# Altium schematic sheet Photo-interrupter.SchDoc
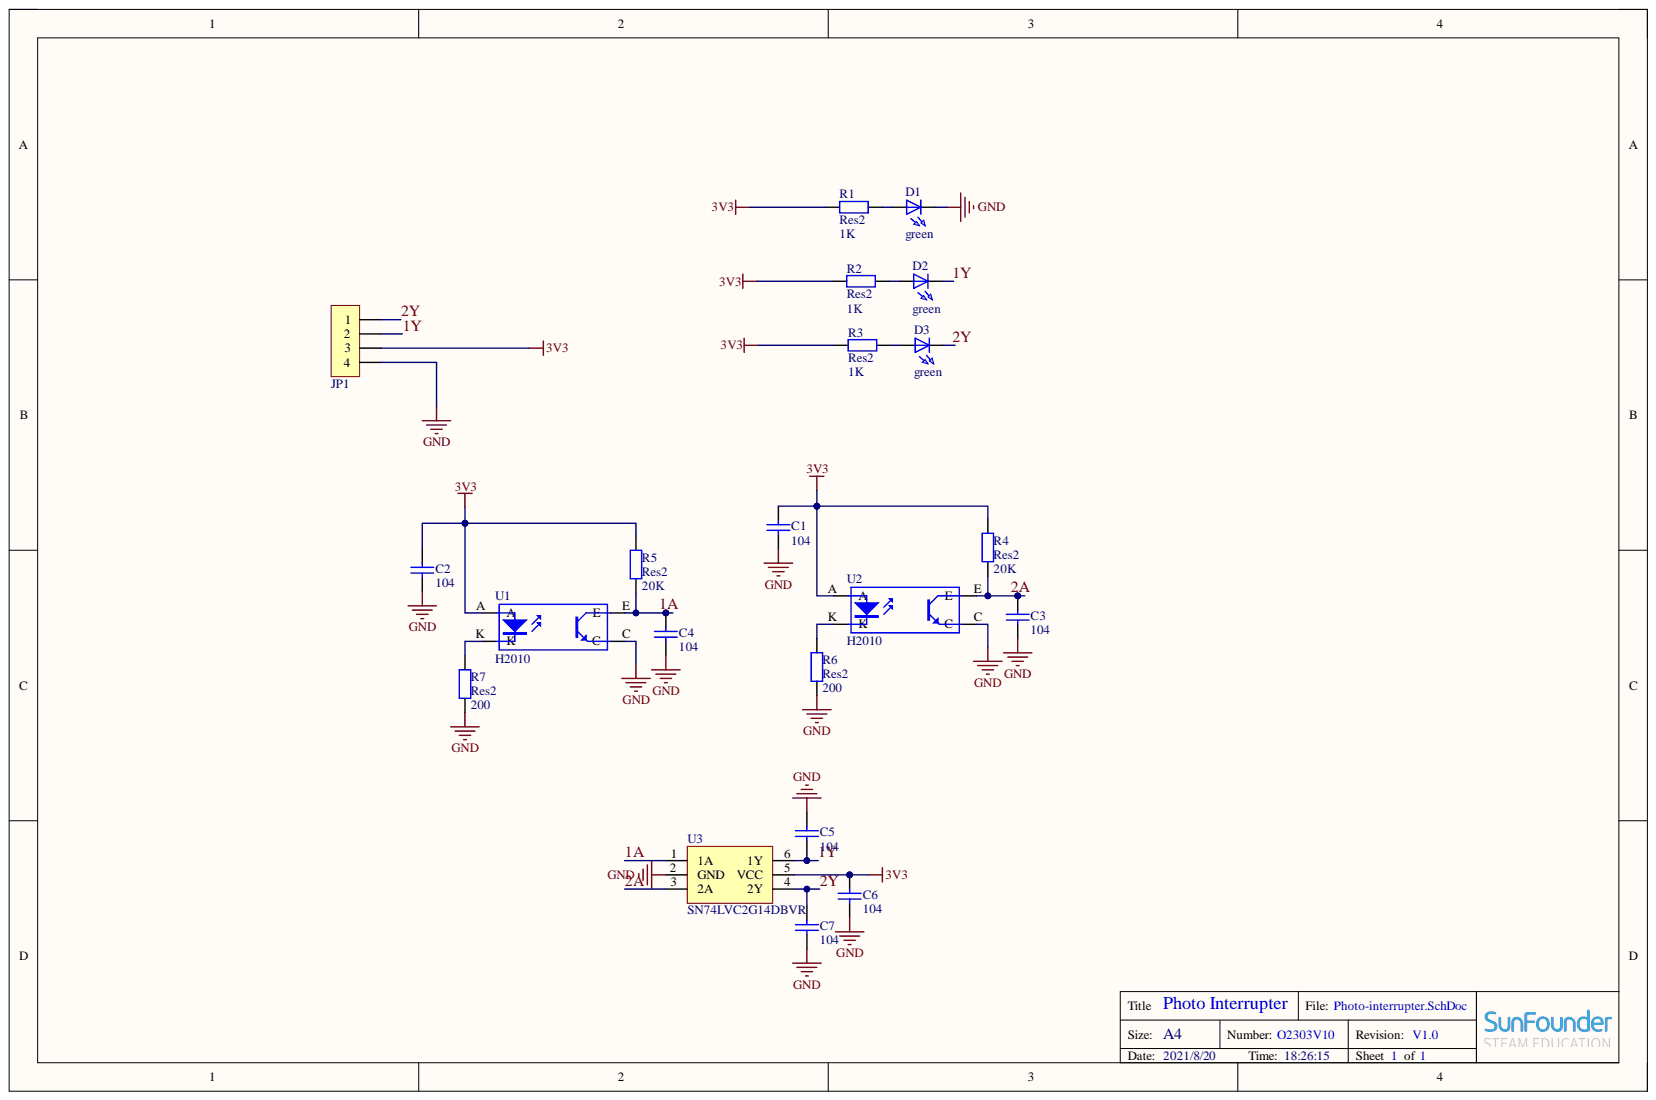
[diagram p0: Photo-interrupter.SchDoc]

## Parts
C1  104  [0603C]
C2  104  [0603C]
C3  104  [0603C]
C4  104  [0603C]
C5  104  [0603C]
C6  104  [0603C]
C7  104  [0603C]
D1  green  [LED_0805]
D2  green  [LED_0805]
D3  green  [LED_0805]
JP1  HDR-1X4  [XH2.54-WS-4P]  (value not drawn)
R1  Res2  value 1K  [0603R]
R2  Res2  value 1K  [0603R]
R3  Res2  value 1K  [0603R]
R4  Res2  value 20K  [0603R]
R5  Res2  value 20K  [0603R]
R6  Res2  value 200  [0603R]
R7  Res2  value 200  [0603R]
U1  H2010  [H2010]
U2  H2010  [H2010]
U3  SN74LVC2G14DBVR  [SOT23-6]

## Nets
3V3: C1.1 C2.1 C6.1 JP1.3 R1.1 R2.1 R3.1 R4.2 R5.2 U1.A U2.A U3.5(VCC)
GND: C1.2 C2.2 C3.2 C4.2 C5.1 C6.2 C7.2 D1.C JP1.4 R6.1 R7.1 U1.C U2.C U3.2(GND)
1A: C4.1 R5.1 U1.E U3.1(1A)
1Y: C5.2 D2.C JP1.2 U3.6(1Y)
2A: C3.1 R4.1 U2.E U3.3(2A)
2Y: C7.1 D3.C JP1.1 U3.4(2Y)
NetD1_A: D1.A R1.2
NetD2_A: D2.A R2.2
NetD3_A: D3.A R3.2
NetR6_2: R6.2 U2.K
NetR7_2: R7.2 U1.K

## Library data of the parts
C1-C7 = Cap: Capacitor; Component Kind: Standard; LatestRevisionDate: 17-Jul-2002; LatestRevisionNote: Re-released for DXP Platform.; Library Name: Integrated_Library.IntLib; PackageDescription: Radial Cap, Thru-Hole; 2 Leads; 0.3 in Pin Spacing; PackageReference: RAD-0.3; Published: 8-Jun-2000; Value: 104; Publisher: Altium Limited
D1-D3 = LED1: RX; Component Kind: Standard; Library Name: Integrated_Library.IntLib
JP1 = Header 4: 4P接插件; 版权归属: 源创客; BOM名称: 4P接插件; Component Kind: Standard; Library Name: 4.5   - 接插件-脚距2.54.SchLib; 学习资源: www.ooocke.com
R1-R7 = Res2: Resistor; Component Kind: Standard; LatestRevisionDate: 17-Jul-2002; LatestRevisionNote: Re-released for DXP Platform.; Library Name: Miscellaneous Devices.IntLib; PackageDescription: Axial Device, Thru-Hole; 2 Leads; 0.4 in Pin Spacing; PackageReference: AXIAL-0.4; Published: 8-Jun-2000; Signal Integrity: Res; Simulation: RESISTOR; Publisher: Altium Limited
U1 U2 = H2010: 4-Pin Phototransistor Optocoupler; Component Kind: Standard; DatasheetVersion: Nov-2003; LatestRevisionDate: 04-Mar-2005; LatestRevisionNote: Sch pins 3 & 4 Swapped.; PackageReference: DIP-4; Published: 24-Mar-1999; Library Name: speed.SchLib; Publisher: Altium Limited
U3 = SN74LVC2G04DBVR: Component Kind: Standard; Library Name: speed.SchLib
